# Altium schematic sheet 2. ISO-IN.SchDoc("2. ISO-IN")
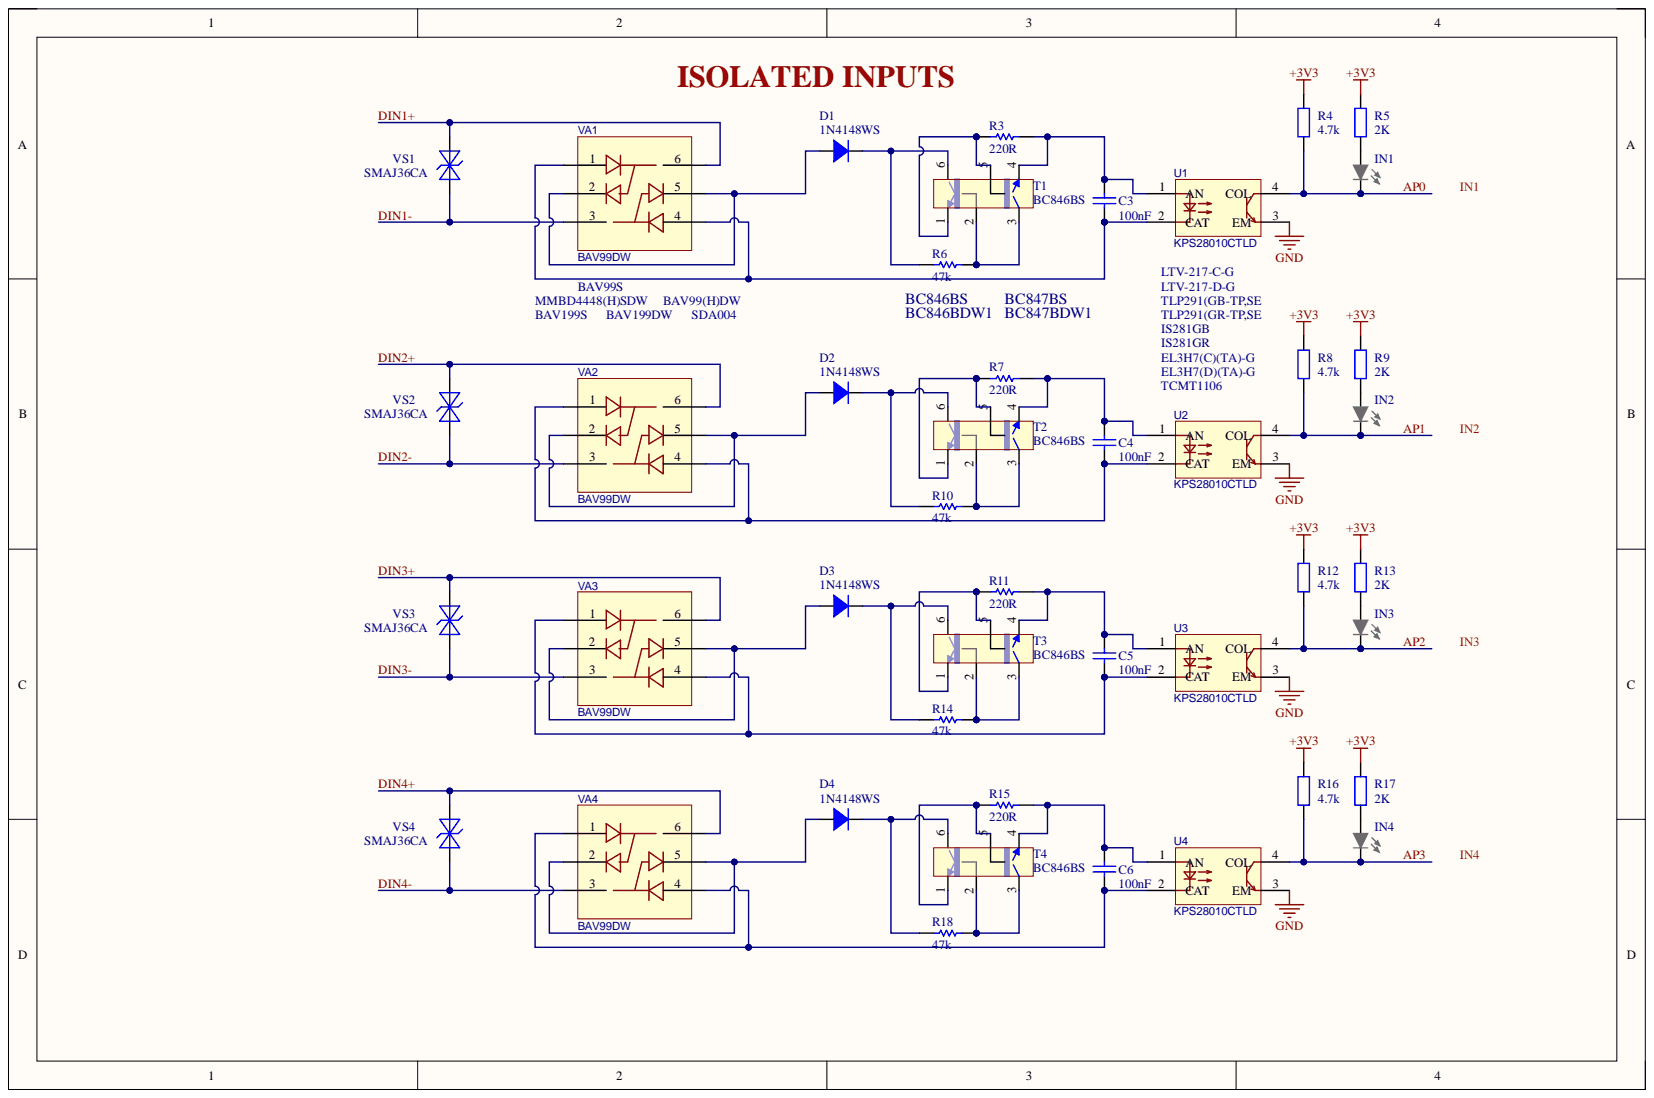
[diagram p0: 2. ISO-IN.SchDoc]

## Parts
C3  100nF  [CAPC1608X100N]
C4  100nF  [CAPC1608X100N]
C5  100nF  [CAPC1608X100N]
C6  100nF  [CAPC1608X100N]
D1  1N4148WS  [SOD323FL]
D2  1N4148WS  [SOD323FL]
D3  1N4148WS  [SOD323FL]
D4  1N4148WS  [SOD323FL]
IN1  GREEN  [LED0603_GREEN]  (value not drawn)
IN2  GREEN  [LED0603_GREEN]  (value not drawn)
IN3  GREEN  [LED0603_GREEN]  (value not drawn)
IN4  GREEN  [LED0603_GREEN]  (value not drawn)
R3  220R  [RESC1608X50N]
R4  4.7k  [RESC-0603 (1608) - HD]
R5  2K  [R0402]
R6  47k  [RESC1608X50N]
R7  220R  [RESC1608X50N]
R8  4.7k  [RESC-0603 (1608) - HD]
R9  2K  [R0402]
R10  47k  [RESC1608X50N]
R11  220R  [RESC1608X50N]
R12  4.7k  [RESC-0603 (1608) - HD]
R13  2K  [R0402]
R14  47k  [RESC1608X50N]
R15  220R  [RESC1608X50N]
R16  4.7k  [RESC-0603 (1608) - HD]
R17  2K  [R0402]
R18  47k  [RESC1608X50N]
T1  BC846BS  [SOT363]
T2  BC846BS  [SOT363]
T3  BC846BS  [SOT363]
T4  BC846BS  [SOT363]
U1  KPS28010CTLD  [SOP-4_L4.4-W2.8-P1.27-LS7.0-BR]
U2  KPS28010CTLD  [SOP-4_L4.4-W2.8-P1.27-LS7.0-BR]
U3  KPS28010CTLD  [SOP-4_L4.4-W2.8-P1.27-LS7.0-BR]
U4  KPS28010CTLD  [SOP-4_L4.4-W2.8-P1.27-LS7.0-BR]
VA1  BAV99DW  [SOT363]
VA2  BAV99DW  [SOT363]
VA3  BAV99DW  [SOT363]
VA4  BAV99DW  [SOT363]
VS1  SMAJ36CA  [SMAJ_BI]
VS2  SMAJ36CA  [SMAJ_BI]
VS3  SMAJ36CA  [SMAJ_BI]
VS4  SMAJ36CA  [SMAJ_BI]

## Nets
+3V3: R4.1 R5.2 R8.1 R9.2 R12.1 R13.2 R16.1 R17.2
GND: U1.3 U2.3 U3.3 U4.3
AP0: IN1.K R4.2 U1.4
AP1: IN2.K R8.2 U2.4
AP2: IN3.K R12.2 U3.4
AP3: IN4.K R16.2 U4.4
DIN1+: VA1.6 VS1.2
DIN1-: VA1.3 VS1.1
DIN2+: VA2.6 VS2.2
DIN2-: VA2.3 VS2.1
DIN3+: VA3.6 VS3.2
DIN3-: VA3.3 VS3.1
DIN4+: VA4.6 VS4.2
DIN4-: VA4.3 VS4.1
NetC3_1: C3.1 U1.2 VA1.1 VA1.4
NetC3_2: C3.2 R3.2 T1.4 U1.1
NetC4_1: C4.1 U2.2 VA2.1 VA2.4
NetC4_2: C4.2 R7.2 T2.4 U2.1
NetC5_1: C5.1 U3.2 VA3.1 VA3.4
NetC5_2: C5.2 R11.2 T3.4 U3.1
NetC6_1: C6.1 U4.2 VA4.1 VA4.4
NetC6_2: C6.2 R15.2 T4.4 U4.1
NetD1_1: D1.1 R6.1 T1.6
NetD1_2: D1.2 VA1.2 VA1.5
NetD2_1: D2.1 R10.1 T2.6
NetD2_2: D2.2 VA2.2 VA2.5
NetD3_1: D3.1 R14.1 T3.6
NetD3_2: D3.2 VA3.2 VA3.5
NetD4_1: D4.1 R18.1 T4.6
NetD4_2: D4.2 VA4.2 VA4.5
NetIN1_A: IN1.A R5.1
NetIN2_A: IN2.A R9.1
NetIN3_A: IN3.A R13.1
NetIN4_A: IN4.A R17.1
NetR3_1: R3.1 T1.1 T1.5
NetR6_2: R6.2 T1.2 T1.3
NetR7_1: R7.1 T2.1 T2.5
NetR10_2: R10.2 T2.2 T2.3
NetR11_1: R11.1 T3.1 T3.5
NetR14_2: R14.2 T3.2 T3.3
NetR15_1: R15.1 T4.1 T4.5
NetR18_2: R18.2 T4.2 T4.3

## Library data of the parts
C3-C6 = Cap: CAP CER 0.1UF 50V X7R 0603; Component Kind: Standard; LatestRevisionDate: 17-Jul-2002; LatestRevisionNote: Re-released for DXP Platform.; Library Name: Miscellaneous Devices.IntLib; PackageDescription: Radial Cap, Thru-Hole; 2 Leads; 0.3 in Pin Spacing; PackageReference: RAD-0.3; Published: 8-Jun-2000; Signal Integrity: Cap; Simulation: CAP; Value: 100nF; Publisher: Altium Limited
D1-D4 = DIODE_1N4148WS: DIODE GEN PURP 75V 150MA SOD323F; Manufacturer: ON Semiconductor / Fairchild; Element Configuration: Single; Forward Voltage: 1V; Max Forward Surge Current (Ifsm): 2A; Max Reverse Current: 5uA; Power Dissipation: 200mW; Recovery Time: 4ns; Average Rectified Current: 150mA; Category: Rectifier Diodes; Component Kind: Standard; ComponentLink1Description: https://datasheet.ciiva.com/25968/1n914bws-25968945.pdf?src-supplier=Avnet+Europe; ComponentLink1URL: https://datasheet.ciiva.com/25968/1n914bws-25968945.pdf?src-supplier=Avnet+Europe; ComponentLink2Description: https://datasheet.ciiva.com/30479/0900766b810e1cd3-30479206.pdf?src-supplier=RSComponents; ComponentLink2URL: https://datasheet.ciiva.com/30479/0900766b810e1cd3-30479206.pdf?src-supplier=RSComponents; ComponentLink3Description: https://datasheet.ciiva.com/8300/1n914bws-8300360.pdf?src-supplier=Avnet; ComponentLink3URL: https://datasheet.ciiva.com/8300/1n914bws-8300360.pdf?src-supplier=Avnet; ComponentLink4Description: https://datasheet.ciiva.com/15208/getdatasheetpartid-127819-15208358.pdf?src-supplier=Verical; ComponentLink4URL: https://datasheet.ciiva.com/15208/getdatasheetpartid-127819-15208358.pdf?src-supplier=Verical; ComponentLink5Description: https://datasheet.ciiva.com/910/1n4148ws-910381.pdf?src-supplier=Digi-Key; ComponentLink5URL: https://datasheet.ciiva.com/910/1n4148ws-910381.pdf?src-supplier=Digi-Key; ComponentLink6Description: https://datasheet.ciiva.com/910/1n4148ws-910382.pdf?src-supplier=Digi-Key; ComponentLink6URL: https://datasheet.ciiva.com/910/1n4148ws-910382.pdf?src-supplier=Digi-Key; ComponentLink7Description: https://datasheet.ciiva.com/910/1n4148ws-910380.pdf?src-supplier=Digi-Key; ComponentLink7URL: https://datasheet.ciiva.com/910/1n4148ws-910380.pdf?src-supplier=Digi-Key; Contact Plating: Tin,Matte; Forward Current: 150mA; Height: 1mm; Lead Free: Lead Free; Length: 1.8mm; Manufacturer Part Number: 1N4148WS; Max Operating Temperature: 150°C; Max Repetitive Reverse Voltage (Vrrm): 75V; Max Reverse Voltage (DC): 75V; Min Operating Temperature: -55°C; Mount: Surface Mount; Number of Pins: 2; Package Quantity: 1; Packaging: Tape and Reel; Pricing 1: 1=0.21000, 10=0.19200, 100=0.10460, 500=0.06436, 1000=0.04388 (USD); Radiation Hardening: No; REACH SVHC: No SVHC; Reverse Recovery Time: 4ns; Reverse Voltage: 75V; RoHS: Yes; RoHS Compliant: Yes; Series: -; Stock 1: 680869; Supplier 1: Digi-Key; Supplier Part Number 1: 1N4148WSFSCT-ND; Weight: 0.0276g; Width: 1.35mm; Library Name: Bokra.SCHLIB
IN1-IN4 = LED0603_GREEN: LED GREEN CLEAR SMD; Manufacturer: XINGLIGHT; Manufacturer Part Number: XL-1608SYGC-06; Dominant Wavelength: 571nm; Forward Current: 30mA; Forward Voltage: 2V; Lens Color: Colorless; Lens Style: Rectangular,Colorless; Luminous Intensity: 35mcd; Max Power Dissipation: 75mW; Number of Elements: 1; Reverse Voltage: 5V; Test Current: 20mA; Viewing Angle: 130°; Wavelength: 574nm; Case Code (Imperial): 0603; Case Code (Metric): 1608; Case/Package: 0603; Category: LEDs; Color: Green; Component Kind: Standard; ComponentLink1Description: https://datasheet.ciiva.com/2109/ltst-c191kgkt-2109973.pdf?src-supplier=Digi-Key; ComponentLink1URL: https://datasheet.ciiva.com/2109/ltst-c191kgkt-2109973.pdf?src-supplier=Digi-Key; Depth: 0.8mm; Height: 0.55mm; Illumination Color: Green; Lead Free: Lead Free; Length: 1.6mm; Lens Transparency: Clear; Library Name: Schematic_Lib.SchLib; Max Operating Temperature: 85°C; Min Operating Temperature: -55°C; Mount: Surface Mount; Number of LEDs: 1; Number of Pins: 2; Package Quantity: 1; Packaging: Tape and Reel; Power Dissipation: 75mW; Power Rating: 75mW; Pricing 1: 1=0.26000, 10=0.14100, 100=0.06560, 500=0.06060, 1000=0.04747, 2000=0.04646 (USD); Radiation Hardening: No; RoHS: Yes; RoHS Compliant: Yes; Stock 1: 910352; Supplier 1: LCSC; Supplier Part Number 1: C965805; Width: 0.8mm
R3 R6 R7 R10 R11 R14 R15 R18 = Res1: Resistor; Component Kind: Standard; LatestRevisionDate: 17-Jul-2002; LatestRevisionNote: Re-released for DXP Platform.; Library Name: Miscellaneous Devices.IntLib; PackageDescription: Axial Device, Thru-Hole; 2 Leads; 0.3 in Pin Spacing; PackageReference: AXIAL-0.3; Published: 8-Jun-2000; Signal Integrity: Res; Simulation: RESISTOR; Publisher: Altium Limited
  R3 R7 R11 R15: Value: 220R
  R6 R10 R14 R18: Value: 47k
R4 R8 R12 R16 = RC0603FR-074K7L: RES 4.7K OHM 1% 1/10W 0603; MFR: Yageo; Category: RESISTOR; Component Kind: Standard; LCSC #: C99782; MPN: RC0603FR-074K7L; Solder: RES-0603; URL: https://www.digikey.com/en/products/detail/yageo/RC0603FR-074K7L/727212; Value: 4.7k; Library Name: Bokra.SCHLIB
R5 R9 R13 R17 = 0402WGF2001TCE: -55℃~+155℃ 2kΩ 50V 62.5mW Thick Film Resistor ±1% ±100ppm/℃ 0402 Chip Resistor - Surface Mount ROHS; Component Kind: Standard; LCSC #: C4109; MPN: 0402WGF2001TCE; Value: 2K; Library Name: Bokra.SCHLIB
T1-T4 = BC846BS: Bipolar (BJT) Transistor Array 2 NPN (Dual) 65V 100mA 100MHz 300mW Surface Mount 6-TSSOP; MF: Nexperia USA; Availability: Bad; Component Kind: Standard; MP: BC846BS; Package: SOT-363 Nexperia; Price: None; Library Name: IoTextra Octal2.SCHLIB
U1-U4 = KPS28010ATLD: BOM_Manufacturer: COSMO; BOM_Manufacturer Part: KPS28010СTLD; Contributor: LCSC; BOM_JLCPCB Part Class: Extended Part; BOM_Supplier: LCSC; BOM_Supplier Part: C136436; Component Kind: Standard; spicePre: U; spiceSymbolName: KPS28010СTLD; Library Name: IoTextra Octal2.SCHLIB
VA1-VA4 = BAV99S: BOM_Manufacturer: CJ; BOM_JLCPCB Part Class: Extended Part; BOM_Manufacturer Part: BAV99S; BOM_Supplier: LCSC; BOM_Supplier Part: C68987; Component Kind: Standard; spicePre: D; spiceSymbolName: BAV99S; Library Name: IoTextra Octal2.SCHLIB
VS1-VS4 = SMAJxxCA: TVS diode 400W Vr=36V SMA; Component Kind: Standard; PartNumber1: SMAJ36CA; Value: SMAJ36CA; Library Name: IoTextra_Input_2-03.SCHLIB
